# Altium schematic sheet UTHP Edge Connectors v2.SchDoc
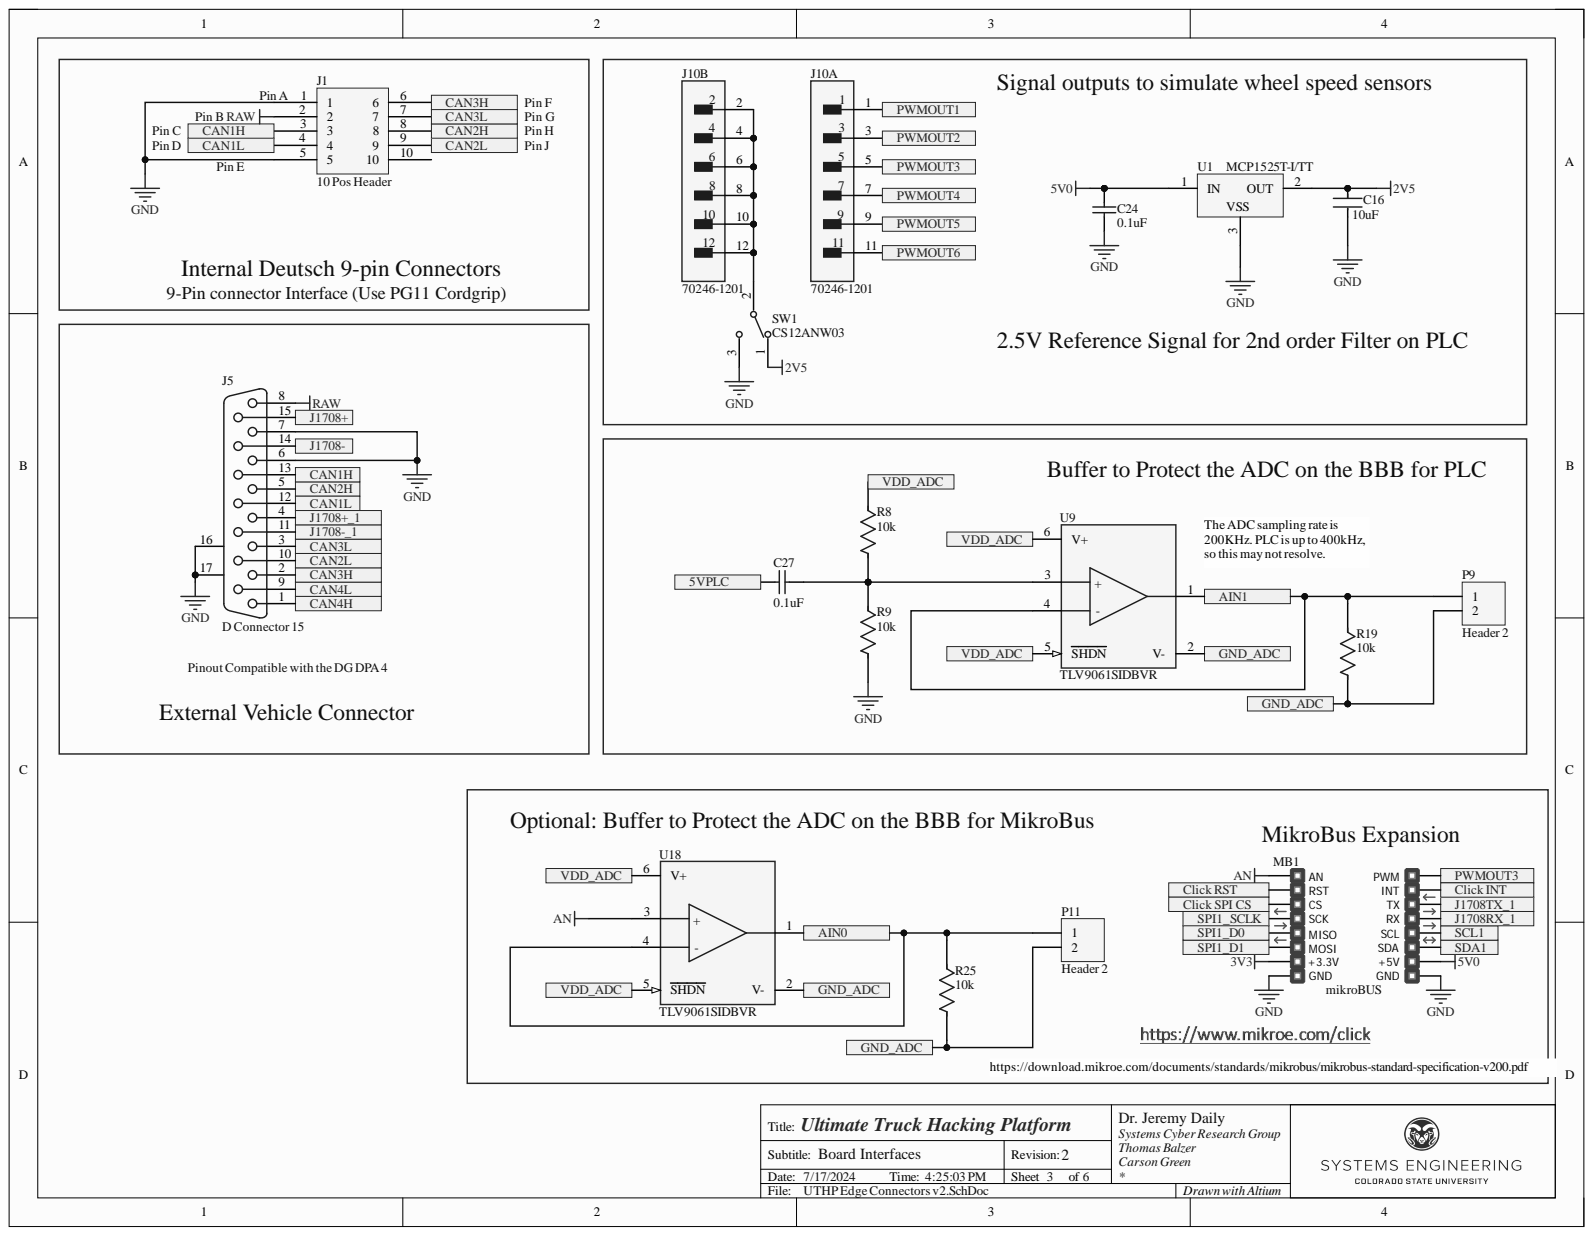
[diagram p0: UTHP Edge Connectors v2.SchDoc]

## Parts
C16  10uF  [FP-MK325A-MFG]
C24  0.1uF  [CAPC1608X90X35LL15T15]
C27  0.1uF  [CAPC1608X90X35LL15T15]
J1  10 Pos Header  [10 pos Mini-Fit Jr. R/A Header]
J5  D Connector 15  [DSUB1.385-2H15]
J10  70246-1201  [FP-70246-1201-0]  (parts A,B)
MB1  mikroBUS  [mikroBUS - Large]  (no BOM)
P9  Header 2  [HDR1X2]
P11  Header 2  [HDR1X2]
R8  10k  [FP-KTR03-IPC_B]
R9  10k  [FP-KTR03-IPC_B]
R19  10k  [FP-KTR03-IPC_B]
R25  10k  [FP-KTR03-IPC_B]
SW1  CS12ANW03  [FP-CS12ANW03-MFG]
U1  MCP1525T-I/TT  [SOT-23-TT3_M]
U9  TLV9061SIDBVR  [FP-DBV0006A-MFG]
U18  TLV9061SIDBVR  [FP-DBV0006A-MFG]

## Ports
5VPLC, AIN0, AIN1, CAN1H, CAN1L, CAN2H, CAN2L, CAN3H, CAN3L, CAN4H, CAN4L, Click INT, Click RST, Click SPI CS, GND_ADC, J1708+, J1708+_1, J1708-, J1708-_1, J1708RX_1, J1708TX_1, PWMOUT1, PWMOUT2, PWMOUT3, PWMOUT4, PWMOUT5, PWMOUT6, SCL1, SDA1, SPI1_D0, SPI1_D1, SPI1_SCLK, VDD_ADC

## Nets
2V5: C16.1 SW1.1 U1.2
3V3: MB1.7(+3.3V)
5V0: C24.1 MB1.10(+5V) U1.1
AN: MB1.1(AN) U18.3
GND: C16.2 C24.2 J1.1 J1.5 J5.6 J5.7 J5.16 J5.17 MB1.8(GND) MB1.9(GND) R9.2 SW1.3 U1.3
RAW: J1.2 J5.8
5VPLC: C27.1 ; port 5VPLC
AIN0: P11.1 R25.1 U18.1 U18.4 ; port AIN0
AIN1: P9.1 R19.1 U9.1 U9.4 ; port AIN1
CAN1H: J1.3 J5.13 ; port CAN1H
CAN1L: J1.4 J5.12 ; port CAN1L
CAN2H: J1.8 J5.5 ; port CAN2H
CAN2L: J1.9 J5.10 ; port CAN2L
CAN3H: J1.6 J5.2 ; port CAN3H
CAN3L: J1.7 J5.3 ; port CAN3L
CAN4H: J5.1 ; port CAN4H
CAN4L: J5.9 ; port CAN4L
Click INT: MB1.15(INT) ; port Click INT
Click RST: MB1.2(RST) ; port Click RST
Click SPI CS: MB1.3(CS) ; port Click SPI CS
GND_ADC: P9.2 P11.2 R19.2 R25.2 U9.2(V-) U18.2(V-) ; port GND_ADC
J1708+: J5.15 ; port J1708+
J1708+_1: J5.4 ; port J1708+_1
J1708-: J5.14 ; port J1708-
J1708-_1: J5.11 ; port J1708-_1
J1708RX_1: MB1.13(RX) ; port J1708RX_1
J1708TX_1: MB1.14(TX) ; port J1708TX_1
PWMOUT1: J10.1 ; port PWMOUT1
PWMOUT2: J10.3 ; port PWMOUT2
PWMOUT3: J10.5 MB1.16(PWM) ; port PWMOUT3
PWMOUT4: J10.7 ; port PWMOUT4
PWMOUT5: J10.9 ; port PWMOUT5
PWMOUT6: J10.11 ; port PWMOUT6
SCL1: MB1.12(SCL) ; port SCL1
SDA1: MB1.11(SDA) ; port SDA1
SPI1_D0: MB1.5(MISO) ; port SPI1_D0
SPI1_D1: MB1.6(MOSI) ; port SPI1_D1
SPI1_SCLK: MB1.4(SCK) ; port SPI1_SCLK
VDD_ADC: R8.1 U9.5(SHDN) U9.6(V+) U18.5(SHDN) U18.6(V+) ; port VDD_ADC
NetC27_2: C27.2 R8.2 R9.1 U9.3
NetJ10_2: J10.2 J10.4 J10.6 J10.8 J10.10 J10.12 SW1.2

Not connected: J1 10

## Library data of the parts
C16 = CMP-14477-002214-1: CAP CER 10UF 50V X7R 1210 UMK325AB7106KM-T; Manufacturer: Taiyo Yuden; Component Kind: Standard; Library Name: Altium Content Vault; Part Number: UMK325AB7106KM-T; Supplier 1: Digi-Key; Supplier Part Number 1: 587-3167-1-ND
C24 C27 = CMP-2006-04387-1: 0.1 µF ±10% 50V Ceramic Capacitor X7R 0603 (1608 Metric); Manufacturer: KYOCERA AVX; Part Number: KAM15AR71H104KM; Component Kind: Standard; Library Name: Altium Content Vault; Supplier 1: Digi-Key; Supplier Part Number 1: 478-KAM15AR71H104KMTR-ND; Value: 0.1uF
J1 = CMP-025-000000-1: Connector Header Through Hole, Right Angle 10 position 0.165" (4.20mm); Manufacturer: Molex; Value: Molex 10-pos; Component Kind: Standard; Part Number: 39-30-1100; Supplier 1: Digi-Key; Supplier Part Number 1: WM1355-ND; Library Name: CSU Systems Cyber
J5 = CMP-097-000000-1: Receptacle Assembly, 15 Position, Right Angle; PackageDocument: O; Positions: 15; Component Kind: Standard; Component Type: Standard; DatasheetDocument: 1-Sep-99; Design Item ID: D Connector 15; Gender: Receptacle; LatestRevisionDate: 17-Jul-2002; LatestRevisionNote: Re-released for DXP Platform.; Orientation: Right Angle; PackageReference: 788757; Pin Count: 17; Published: 15-Jun-2001; Signal Integrity: Connector; Source: Miscellaneous Connectors.SchLib; Library Name: CSU Systems Cyber; Publisher: Altium Limited
J10 = CMP-00257-00249961-1: 70246-1201 Conn Shrouded Header (4 Sides) HDR 12 POS 2.54mm Solder ST Top Entry Thru-Hole C-Grid® Tray; NexarPartId: 249961; Connector Type: Male Pin; Current Rating: 2.5A; Gender: Male; Glow Wire Compliant: Non-compliant; Max Voltage Rating (DC): 250V; Number of Circuits: 12; Color: Black; Component Kind: Standard; Contact Material: Bronze, Gold, Nickel; Contact Mating Length: 6.096mm; Contact Plating: Gold; Depth: 8.89mm; ELV: Compliant; Flammability Rating: UL94 V-0; Height: 9.47mm; Housing Color: Black; Housing Material: Polyester; Lead Free: Lead Free; Length: 22.86mm; Library Name: Altium Content Vault; Max Operating Temperature: 120°C; Min Operating Temperature: -55°C; Mount: Through Hole; Number of Contacts: 12; Number of Positions: 12; Number of Rows: 2; Orientation: Vertical; Pitch: 2.54mm; REACH SVHC: No SVHC; RoHS: Compliant; Row Spacing: 2.54mm; Termination: Solder; Voltage Rating: 250V
MB1 = CMP-068-000000-1: mikroBUS compatible add-on board; Library Name: CSU Systems Cyber
P9 P11 = CMP-096-000070-1: Header, 2-Pin; Component Kind: Standard; Component Type: Standard; Design Item ID: Header 2; LatestRevisionDate: 17-Jul-2002; LatestRevisionNote: Re-released for DXP Platform.; Pin Count: 2; Signal Integrity: Connector; Source: Miscellaneous Connectors.SchLib; Library Name: CSU Systems Cyber; Publisher: Altium Limited
R8 R9 R19 R25 = CMP-08839-030382-1: 10 kOhms ±1% 0.1W, 1/10W Chip Resistor 0603 (1608 Metric) Automotive AEC-Q200, High Voltage Thick Film; Manufacturer: Rohm Semiconductor; Part Number: KTR03EZPF1002; Component Kind: Standard; Library Name: Altium Content Vault; Supplier 1: Digi-Key; Supplier Part Number 1: RHM10.0KAYCT-ND; Value: 10k
SW1 = CMP-31746-000002-1: SWITCH SLIDE SPDT 3A 125V; Actuator Length: 5.1mm; Actuator Material: Nylon; Actuator Type: Standard; Contact Style: Non-Shorting; Electrical Life: 20000Cycles; Illumination Type: Non-Illuminated; Max Voltage Rating (AC): 250V; Mechanical Life: 50000Cycles; Switch Function: On-On; Switch Travel: 2.2mm; Component Kind: Standard; Contact Current Rating: 3A; Contact Material: Copper; Contact Plating: Silver; Current Rating: 3A; Depth: 7.5mm; Flammability Rating: UL94 V-0; Lead Free: Lead Free; Length: 14mm; Library Name: Altium Content Vault; Max Current Rating: 3A; Max Operating Temperature: 85°C; Min Operating Temperature: -30°C; Mount: Through Hole; Orientation: Vertical; Package Quantity: 1; Packaging: Tray; Primary Type: SWITCH; Reach SVHC Compliant: Yes; RoHS Compliant: Yes; Series: CS; Termination: PC Pin; Throw Configuration: SPDT; Voltage Rating (AC): 125V
U1 = CMP-0131-00003-1: 2.5V Voltage Reference, 3-Pin SOT-23, Industrial Temperature, Tape and Reel; Code_IPC: SOT23-3; Component Kind: Standard; DatasheetVersion: revB, Feb-2005; Library Name: Altium Content Vault; PackageDescription: 3-Lead Plastic Small Outline Transistor (TT) [SOT-23]; PackageReference: SOT-23-TT3; PackageVersion: revBB, Aug-2009
U9 U18 = CMP-04895-000376-1: IC CMOS 1 CIRCUIT SOT23-6; Component Kind: Standard; Library Name: Altium Content Vault; RoHS Compliant: Yes
